# Altium schematic sheet TREEPCB.SchDoc
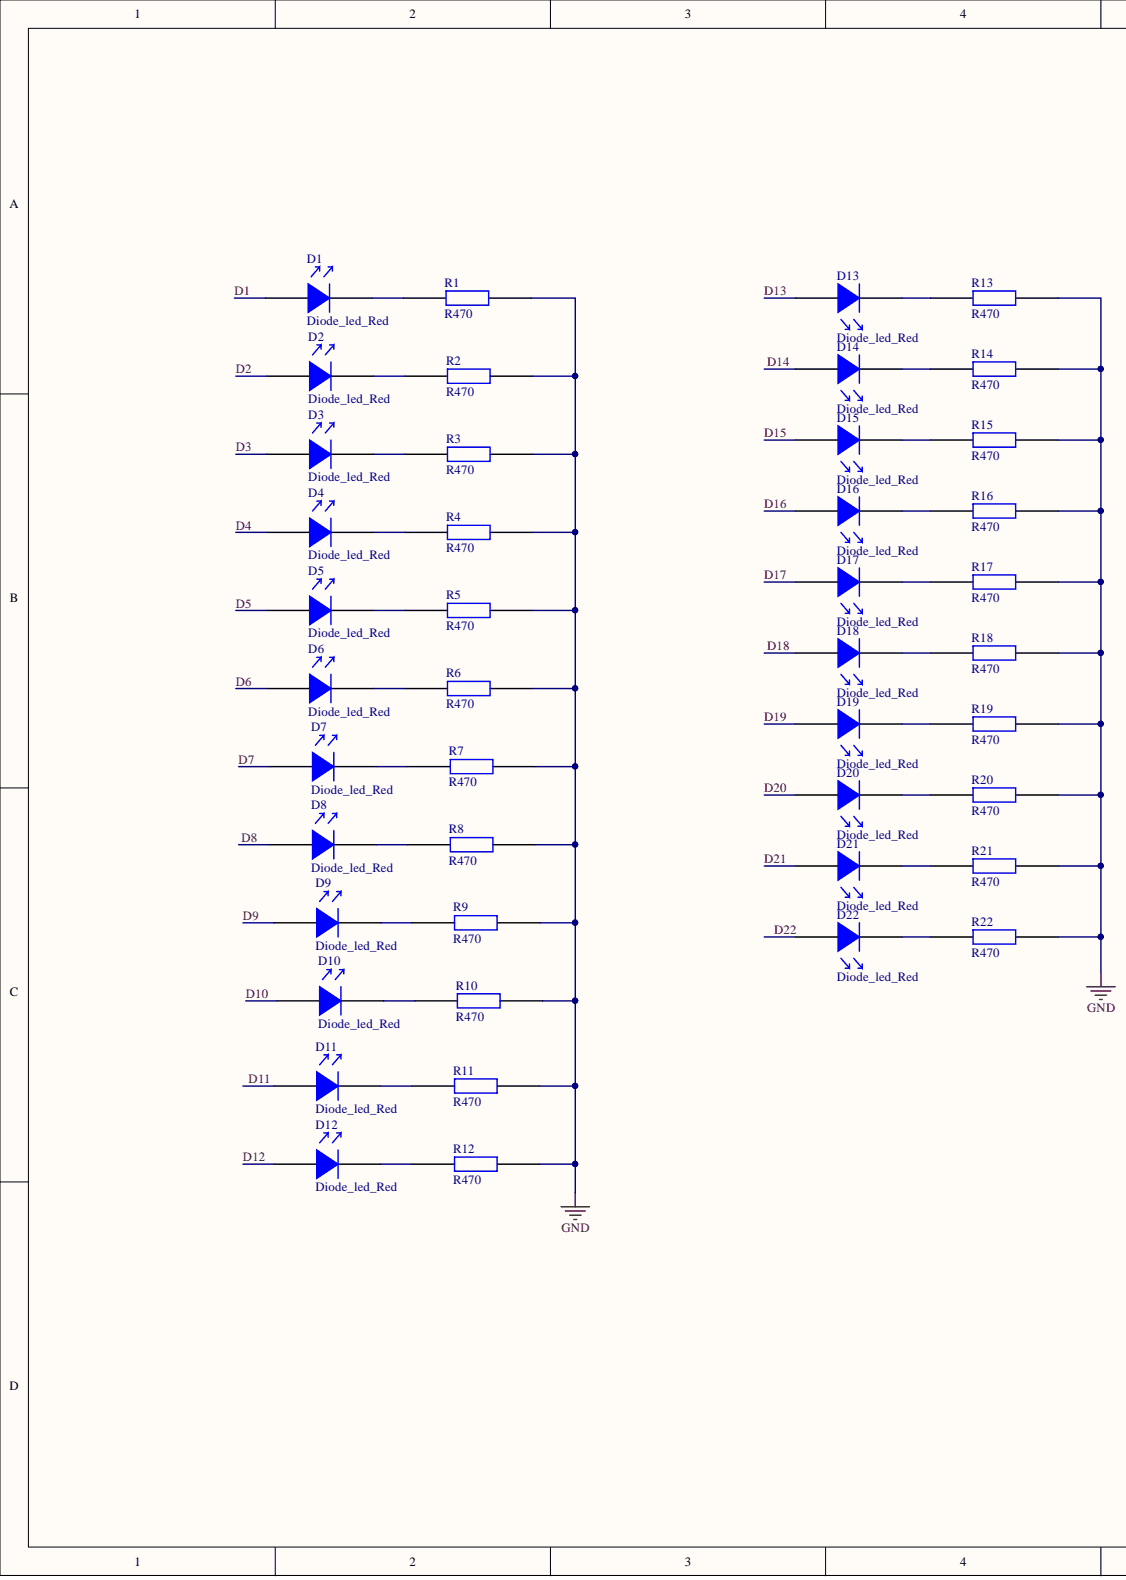
[diagram p0: TREEPCB.SchDoc, left]
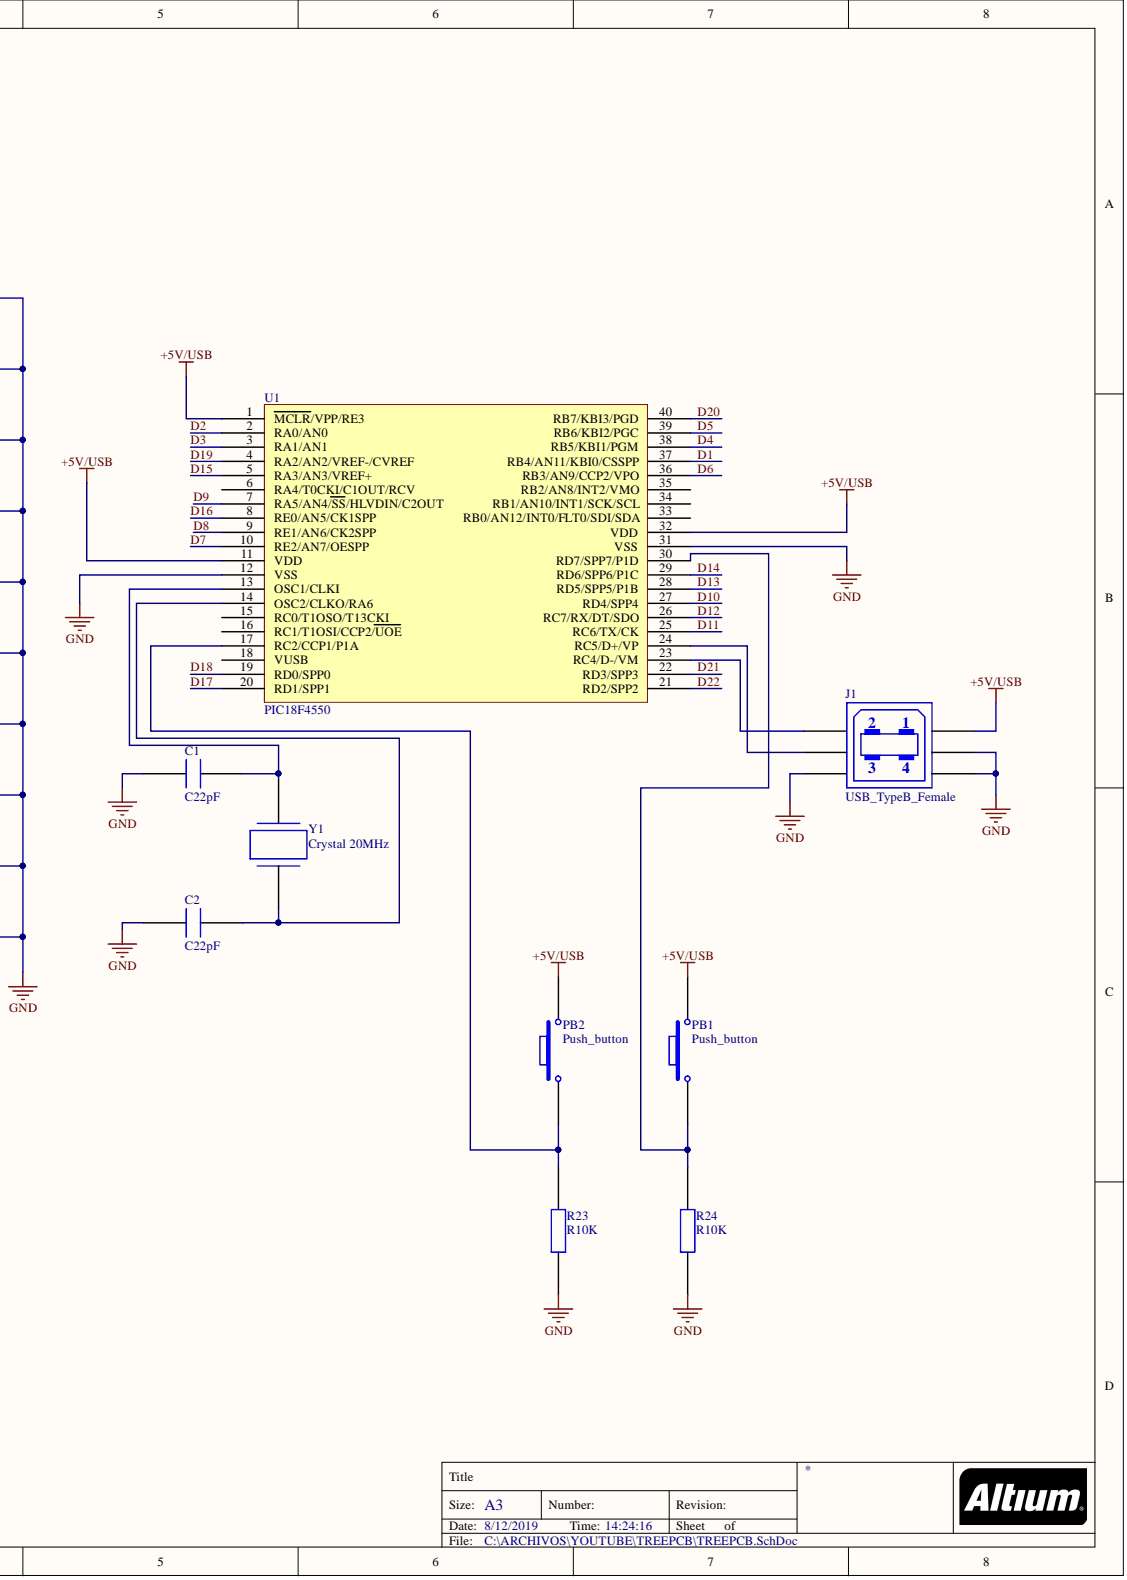
[diagram p1: TREEPCB.SchDoc, right]

## Parts
C1  C22pF  [C22pF_P3mm]
C2  C22pF  [C22pF_P3mm]
D1  Diode_led_Red  [Diode_led_red_3mm]
D2  Diode_led_Red  [Diode_led_red_3mm]
D3  Diode_led_Red  [Diode_led_red_3mm]
D4  Diode_led_Red  [Diode_led_red_3mm]
D5  Diode_led_Red  [Diode_led_red_3mm]
D6  Diode_led_Red  [Diode_led_red_3mm]
D7  Diode_led_Red  [Diode_led_red_3mm]
D8  Diode_led_Red  [Diode_led_red_3mm]
D9  Diode_led_Red  [Diode_led_red_3mm]
D10  Diode_led_Red  [Diode_led_red_3mm]
D11  Diode_led_Red  [Diode_led_red_3mm]
D12  Diode_led_Red  [Diode_led_red_3mm]
D13  Diode_led_Red  [Diode_led_red_3mm]
D14  Diode_led_Red  [Diode_led_red_3mm]
D15  Diode_led_Red  [Diode_led_red_3mm]
D16  Diode_led_Red  [Diode_led_red_3mm]
D17  Diode_led_Red  [Diode_led_red_3mm]
D18  Diode_led_Red  [Diode_led_red_3mm]
D19  Diode_led_Red  [Diode_led_red_3mm]
D20  Diode_led_Red  [Diode_led_red_3mm]
D21  Diode_led_Red  [Diode_led_red_3mm]
D22  Diode_led_Red  [Diode_led_red_3mm]
J1  USB_TypeB_Female  [USB_TYPEB_FEMALE]
PB1  Push_button  [Push_button]
PB2  Push_button  [Push_button]
R1  R470  [R470_P8mm_0.25Watt]
R2  R470  [R470_P8mm_0.25Watt]
R3  R470  [R470_P8mm_0.25Watt]
R4  R470  [R470_P8mm_0.25Watt]
R5  R470  [R470_P8mm_0.25Watt]
R6  R470  [R470_P8mm_0.25Watt]
R7  R470  [R470_P8mm_0.25Watt]
R8  R470  [R470_P8mm_0.25Watt]
R9  R470  [R470_P8mm_0.25Watt]
R10  R470  [R470_P8mm_0.25Watt]
R11  R470  [R470_P8mm_0.25Watt]
R12  R470  [R470_P8mm_0.25Watt]
R13  R470  [R470_P8mm_0.25Watt]
R14  R470  [R470_P8mm_0.25Watt]
R15  R470  [R470_P8mm_0.25Watt]
R16  R470  [R470_P8mm_0.25Watt]
R17  R470  [R470_P8mm_0.25Watt]
R18  R470  [R470_P8mm_0.25Watt]
R19  R470  [R470_P8mm_0.25Watt]
R20  R470  [R470_P8mm_0.25Watt]
R21  R470  [R470_P8mm_0.25Watt]
R22  R470  [R470_P8mm_0.25Watt]
R23  R10K  [R10K_P8mm_0.25Watt]
R24  R10K  [R10K_P8mm_0.25Watt]
U1  PIC18F4550  [DIP40_w_socket]
Y1  Crystal 20MHz  [Crystal 20Mhz]

## Nets
+5V/USB: J1.1 PB1.2 PB2.2 U1.1(MCLR/VPP/RE3) U1.11(VDD) U1.32(VDD)
GND: C1.1 C2.1 J1.4 J1.5 R1.2 R2.2 R3.2 R4.2 R5.2 R6.2 R7.2 R8.2 R9.2 R10.2 R11.2 R12.2 R13.2 R14.2 R15.2 R16.2 R17.2 R18.2 R19.2 R20.2 R21.2 R22.2 R23.1 R24.1 U1.12(VSS) U1.31(VSS)
D1: D1.2 U1.37(RB4/AN11/KBI0/CSSPP)
D2: D2.2 U1.2(RA0/AN0)
D3: D3.2 U1.3(RA1/AN1)
D4: D4.2 U1.38(RB5/KBI1/PGM)
D5: D5.2 U1.39(RB6/KBI2/PGC)
D6: D6.2 U1.36(RB3/AN9/CCP2/VPO)
D7: D7.2 U1.10(RE2/AN7/OESPP)
D8: D8.2 U1.9(RE1/AN6/CK2SPP)
D9: D9.2 U1.7(RA5/AN4/SS/HLVDIN/C2OUT)
D10: D10.2 U1.27(RD4/SPP4)
D11: D11.2 U1.25(RC6/TX/CK)
D12: D12.2 U1.26(RC7/RX/DT/SDO)
D13: D13.2 U1.28(RD5/SPP5/P1B)
D14: D14.2 U1.29(RD6/SPP6/P1C)
D15: D15.2 U1.5(RA3/AN3/VREF+)
D16: D16.2 U1.8(RE0/AN5/CK1SPP)
D17: D17.2 U1.20(RD1/SPP1)
D18: D18.2 U1.19(RD0/SPP0)
D19: D19.2 U1.4(RA2/AN2/VREF-/CVREF)
D20: D20.2 U1.40(RB7/KBI3/PGD)
D21: D21.2 U1.22(RD3/SPP3)
D22: D22.2 U1.21(RD2/SPP2)
NetC1_2: C1.2 U1.13(OSC1/CLKI) Y1.2
NetC2_2: C2.2 U1.14(OSC2/CLKO/RA6) Y1.1
NetD1_1: D1.1 R1.1
NetD2_1: D2.1 R2.1
NetD3_1: D3.1 R3.1
NetD4_1: D4.1 R4.1
NetD5_1: D5.1 R5.1
NetD6_1: D6.1 R6.1
NetD7_1: D7.1 R7.1
NetD8_1: D8.1 R8.1
NetD9_1: D9.1 R9.1
NetD10_1: D10.1 R10.1
NetD11_1: D11.1 R11.1
NetD12_1: D12.1 R12.1
NetD13_1: D13.1 R13.1
NetD14_1: D14.1 R14.1
NetD15_1: D15.1 R15.1
NetD16_1: D16.1 R16.1
NetD17_1: D17.1 R17.1
NetD18_1: D18.1 R18.1
NetD19_1: D19.1 R19.1
NetD20_1: D20.1 R20.1
NetD21_1: D21.1 R21.1
NetD22_1: D22.1 R22.1
NetJ1_2: J1.2 U1.23(RC4/D-/VM)
NetJ1_3: J1.3 U1.24(RC5/D+/VP)
NetPB1_1: PB1.1 R24.2 U1.30(RD7/SPP7/P1D)
NetPB2_1: PB2.1 R23.2 U1.17(RC2/CCP1/P1A)

Not connected: U1 6(RA4/T0CKI/C1OUT/RCV) 15(RC0/T1OSO/T13CKI) 16(RC1/T1OSI/CCP2/UOE) 18(VUSB) 33(RB0/AN12/INT0/FLT0/SDI/SDA) 34(RB1/AN10/INT1/SCK/SCL) 35(RB2/AN8/INT2/VMO)

## Library data of the parts
D1-D22 = Diode_led_Red_3mm: Component Kind: Standard; Library Name: TREEPCB.SchLib
C1 C2 = C22pF: Component Kind: Standard; Library Name: TREEPCB.SchLib
J1 = USB_TypeB_Female: Component Kind: Standard; Library Name: TREEPCB.SchLib
PB1 PB2 = Push_button: Component Kind: Standard; Library Name: TREEPCB.SchLib
R1-R22 = R470: Component Kind: Standard; Library Name: TREEPCB.SchLib
R23 R24 = R10K: Component Kind: Standard; Library Name: TREEPCB.SchLib
U1 = PIC18F4550: Component Kind: Standard; Library Name: TREEPCB.SchLib
Y1 = Crystal 20MHz: Component Kind: Standard; Library Name: TREEPCB.SchLib
